# Altium schematic sheet POWER.SchDoc(POWER)
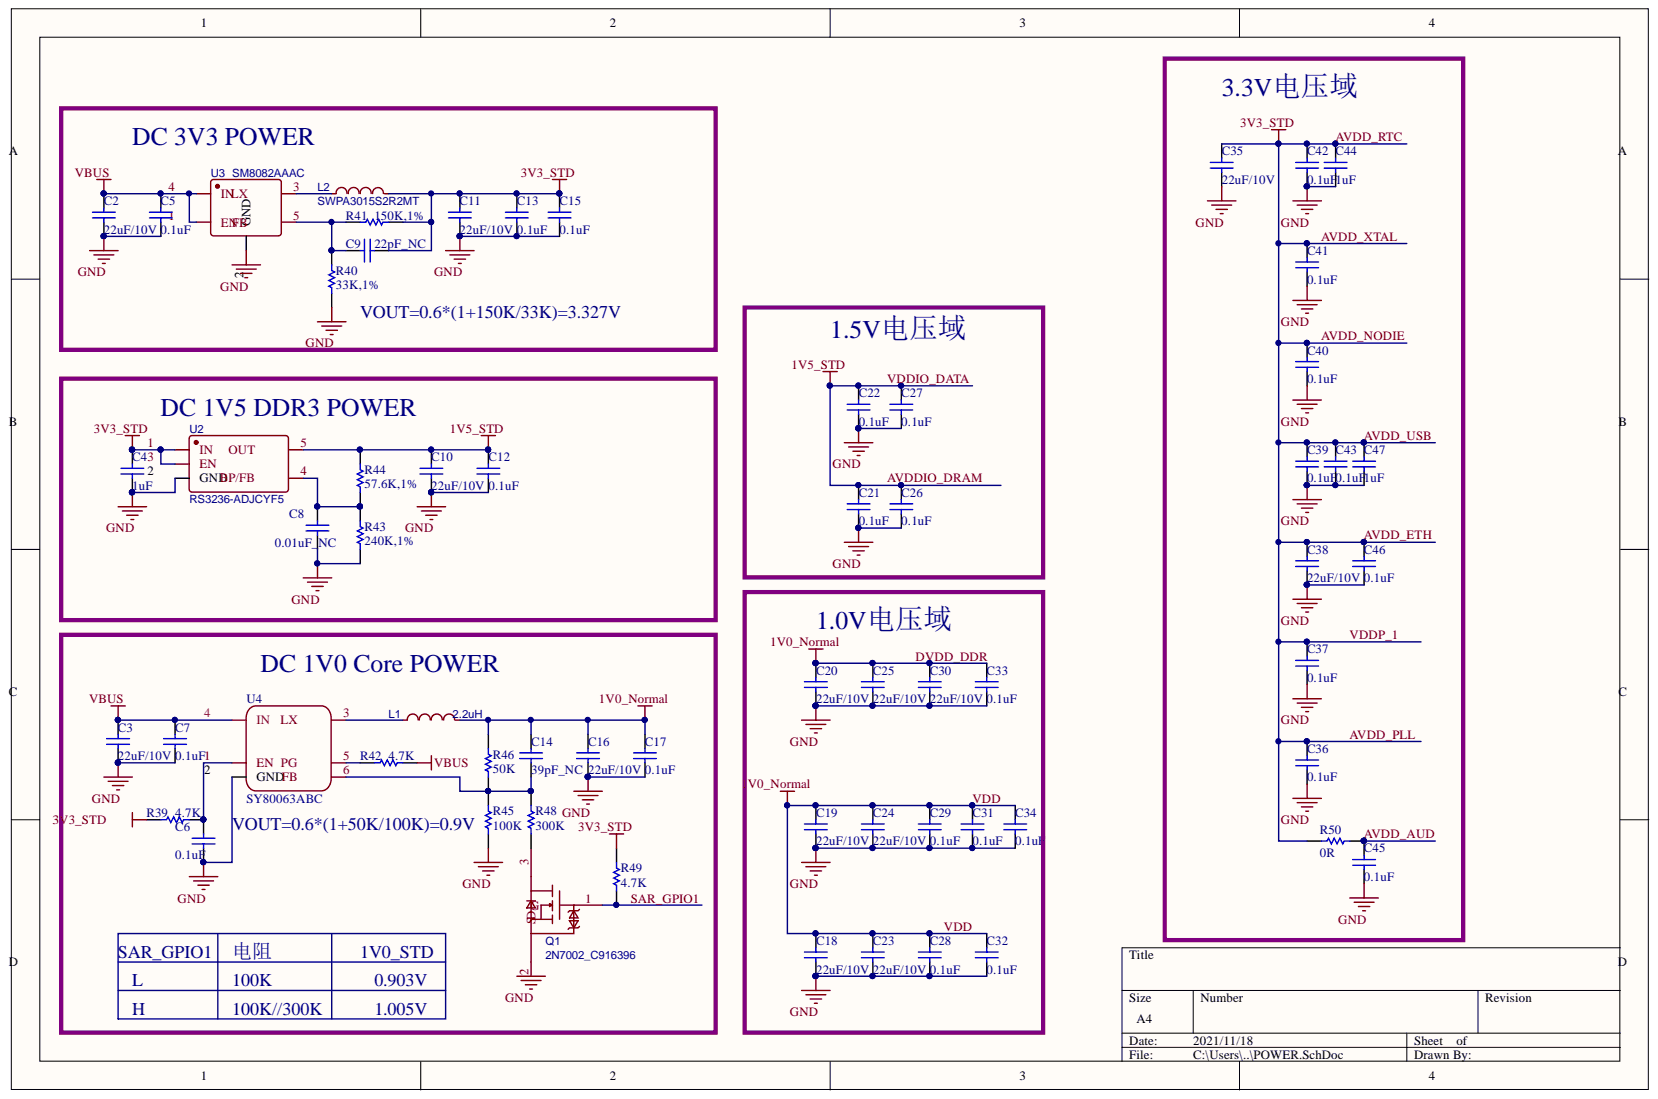
[diagram p0: POWER.SchDoc]

## Parts
C2  22uF/10V  [0603]
C3  22uF/10V  [0603]
C4  1uF  [0402]
C5  0.1uF  [0402]
C6  0.1uF  [0402]
C7  0.1uF  [0402]
C8  0.01uF_NC  [0402]
C9  22pF_NC  [0402]
C10  22uF/10V  [0603]
C11  22uF/10V  [0603]
C12  0.1uF  [0402]
C13  0.1uF  [0402]
C14  39pF_NC  [0402]
C15  0.1uF  [0402]
C16  22uF/10V  [0603]
C17  0.1uF  [0402]
C18  22uF/10V  [0603]
C19  22uF/10V  [0603]
C20  22uF/10V  [0603]
C21  0.1uF  [0402]
C22  0.1uF  [0402]
C23  22uF/10V  [0603]
C24  22uF/10V  [0603]
C25  22uF/10V  [0603]
C26  0.1uF  [0402]
C27  0.1uF  [0402]
C28  0.1uF  [0402]
C29  0.1uF  [0402]
C30  22uF/10V  [0603]
C31  0.1uF  [0402]
C32  0.1uF  [0402]
C33  0.1uF  [0402]
C34  0.1uF  [0402]
C35  22uF/10V  [0603]
C36  0.1uF  [0402]
C37  0.1uF  [0402]
C38  22uF/10V  [0603]
C39  0.1uF  [0402]
C40  0.1uF  [0402]
C41  0.1uF  [0402]
C42  0.1uF  [0402]
C43  0.1uF  [0402]
C44  1uF  [0402]
C45  0.1uF  [0402]
C46  0.1uF  [0402]
C47  1uF  [0402]
L1  2.2uH  [IND-SMD_L4.9-W4.5]
L2  SWPA3015S2R2MT  [IND-SMD_L3.0-W3.0]
Q1  2N7002_C916396  [SOT23]
R39  4.7K  [0402]
R40  33K,1%  [0402]
R41  150K,1%  [0402]
R42  4.7K  [0402]
R43  240K,1%  [0402]
R44  57.6K,1%  [0402]
R45  100K  [0402]
R46  50K  [0402]
R48  300K  [0402]
R49  4.7K  [0402]
R50  0R  [0402]
U2  RS3236-ADJCYF5  [SOT-23-5_L3.0-W1.7-P0.95-LS2.8-BR]
U3  SM8082AAAC  [SOT-23-5_L3.0-W1.7-P0.95-LS2.8-BL]
U4  SY80063ABC  [SOT23-6]

## Nets
GND: C2.1 C3.1 C4.2 C5.2 C6.1 C7.2 C8.2 C10.1 C11.1 C12.2 C13.2 C15.2 C16.1 C17.2 C18.1 C19.1 C20.1 C21.2 C22.2 C23.1 C24.1 C25.1 C26.2 C27.2 C28.2 C29.2 C30.1 C31.2 C32.2 C33.2 C34.2 C35.1 C36.2 C37.2 C38.1 C39.2 C40.2 C41.2 C42.2 C43.2 C44.2 C45.2 C46.2 C47.2 Q1.2 R40.2 R43.2 R45.1 U2.2(GND) U3.2(FB) U4.2(GND)
VBUS: C2.2 C3.2 C5.1 C7.1 R42.2 U3.1(EN) U3.4(IN) U4.4(IN)
AVDD_AUD: C45.1 R50.2
AVDD_ETH: C4.1 C9.2 C11.2 C13.1 C15.1 C35.2 C36.1 C37.1 C38.2 C39.1 C40.1 C41.1 C42.1 C43.1 C44.1 C46.1 C47.1 L2.2 R39.1 R41.2 R49.2 R50.1 U2.1(IN) U2.3(EN)
AVDDIO_DRAM: C10.2 C12.1 C21.1 C22.1 C26.1 C27.1 R44.1 U2.5
DVDD_DDR: C14.2 C16.2 C17.1 C18.2 C19.2 C20.2 C23.2 C24.2 C25.2 C28.1 C29.1 C30.2 C31.1 C32.1 C33.1 C34.1 L1.2 R46.2
SAR_GPIO1: Q1.1 R49.1
NetC6_2: C6.2 R39.2 U4.1(EN)
NetC8_1: C8.1 R43.1 R44.2 U2.4
NetC9_1: C9.1 R40.1 R41.1 U3.5
NetC14_1: C14.1 R45.2 R46.1 R48.2 U4.6
NetL1_1: L1.1 U4.3
NetL2_1: L2.1 U3.3
NetQ1_3: Q1.3 R48.1
NetR42_1: R42.1 U4.5

## Library data of the parts
C2-C47 = Cap: Capacitor; PartNumber: CAP100NF; Component Kind: Standard; LatestRevisionDate: 17-Jul-2002; LatestRevisionNote: Re-released for DXP Platform.; Library Name: Miscellaneous Devices.IntLib; PackageReference: 0402; Published: 8-Jun-2000; Signal Integrity: Cap; Simulation: CAP; Publisher: Altium Limited
  C2 C3 C10 C11 C16 C18-C20 C23-C25 C30 C35 C38: Value: 22uF/10V
  C4 C44 C47: Value: 1uF
  C5-C7 C12 C13 C15 C17 C21 C22 C26-C29 C31-C34 C36 C37 C39-C43 C45 C46: Value: 0.1uF
  C8: Value: 0.01uF_NC
  C9: Value: 22pF_NC
  C14: Value: 39pF_NC
L1 = 2.2uH: BOM_Manufacturer: Jinlai; BOM_Manufacturer Part: JSHC0420-2R2M; Contributor: LCSC; nameAlias: Inductance; BOM_Supplier: LCSC; BOM_Supplier Part: C386778; Component Kind: Standard; spicePre: L; spiceSymbolName: JSHC0420-2R2M
L2 = 2.2uH: BOM_Manufacturer: Sunlord; nameAlias: Inductance; BOM_JLCPCB Part Class: Extended Part; BOM_Manufacturer Part: SWPA3015S2R2MT; BOM_Supplier: LCSC; BOM_Supplier Part: C43389; Component Kind: Standard; Library Name: IND.SCHLIB; spicePre: L; spiceSymbolName: SWPA3015S2R2MT
Q1 = 2N7002_C916396: BOM_Manufacturer Part: 2N7002; Contributor: LCSC; BOM_JLCPCB Part Class: Extended Part; BOM_Supplier: LCSC; BOM_Supplier Part: C916396; Component Kind: Standard; Library Name: HM2.SchLib; spicePre: Q; spiceSymbolName: 2N7002_C916396
R39-R46 R48-R50 = Res1: Resistor; PartNumber: RES2.2K; Component Kind: Standard; LatestRevisionDate: 17-Jul-2002; LatestRevisionNote: Re-released for DXP Platform.; Library Name: Miscellaneous Devices.IntLib; PackageReference: 0402; Published: 8-Jun-2000; Signal Integrity: Res; Simulation: RESISTOR; Publisher: Altium Limited
  R39 R42 R49: Value: 4.7K
  R40: Value: 33K,1%
  R41: Value: 150K,1%
  R43: Value: 240K,1%
  R44: Value: 57.6K,1%
  R45: Value: 100K
  R46: Value: 50K
  R48: Value: 300K
  R50: Value: 0R
U2 = RS3236-ADJCYF5: BOM_Manufacturer: Jiangsu RUNIC Tech; Contributor: LCSC; BOM_JLCPCB Part Class: Extended Part; BOM_Manufacturer Part: RS3236-ADJCYF5; BOM_Supplier: LCSC; BOM_Supplier Part: C379352; Component Kind: Standard; Library Name: HM2.SchLib; spicePre: U; spiceSymbolName: RS3236-ADJCYF5
U3 = SM8082AAAC: BOM_Manufacturer: Silergy Corp; Contributor: LCSC; BOM_JLCPCB Part Class: Extended Part; BOM_Manufacturer Part: SM8082AAAC; BOM_Supplier: LCSC; BOM_Supplier Part: C479068; Component Kind: Standard; Library Name: HM2.SchLib; spicePre: U; spiceSymbolName: SM8082AAAC
U4 = SY80063ABC: Component Kind: Standard; Library Name: HM2.SchLib
